# Altium schematic sheet LCD_Display.SchDoc
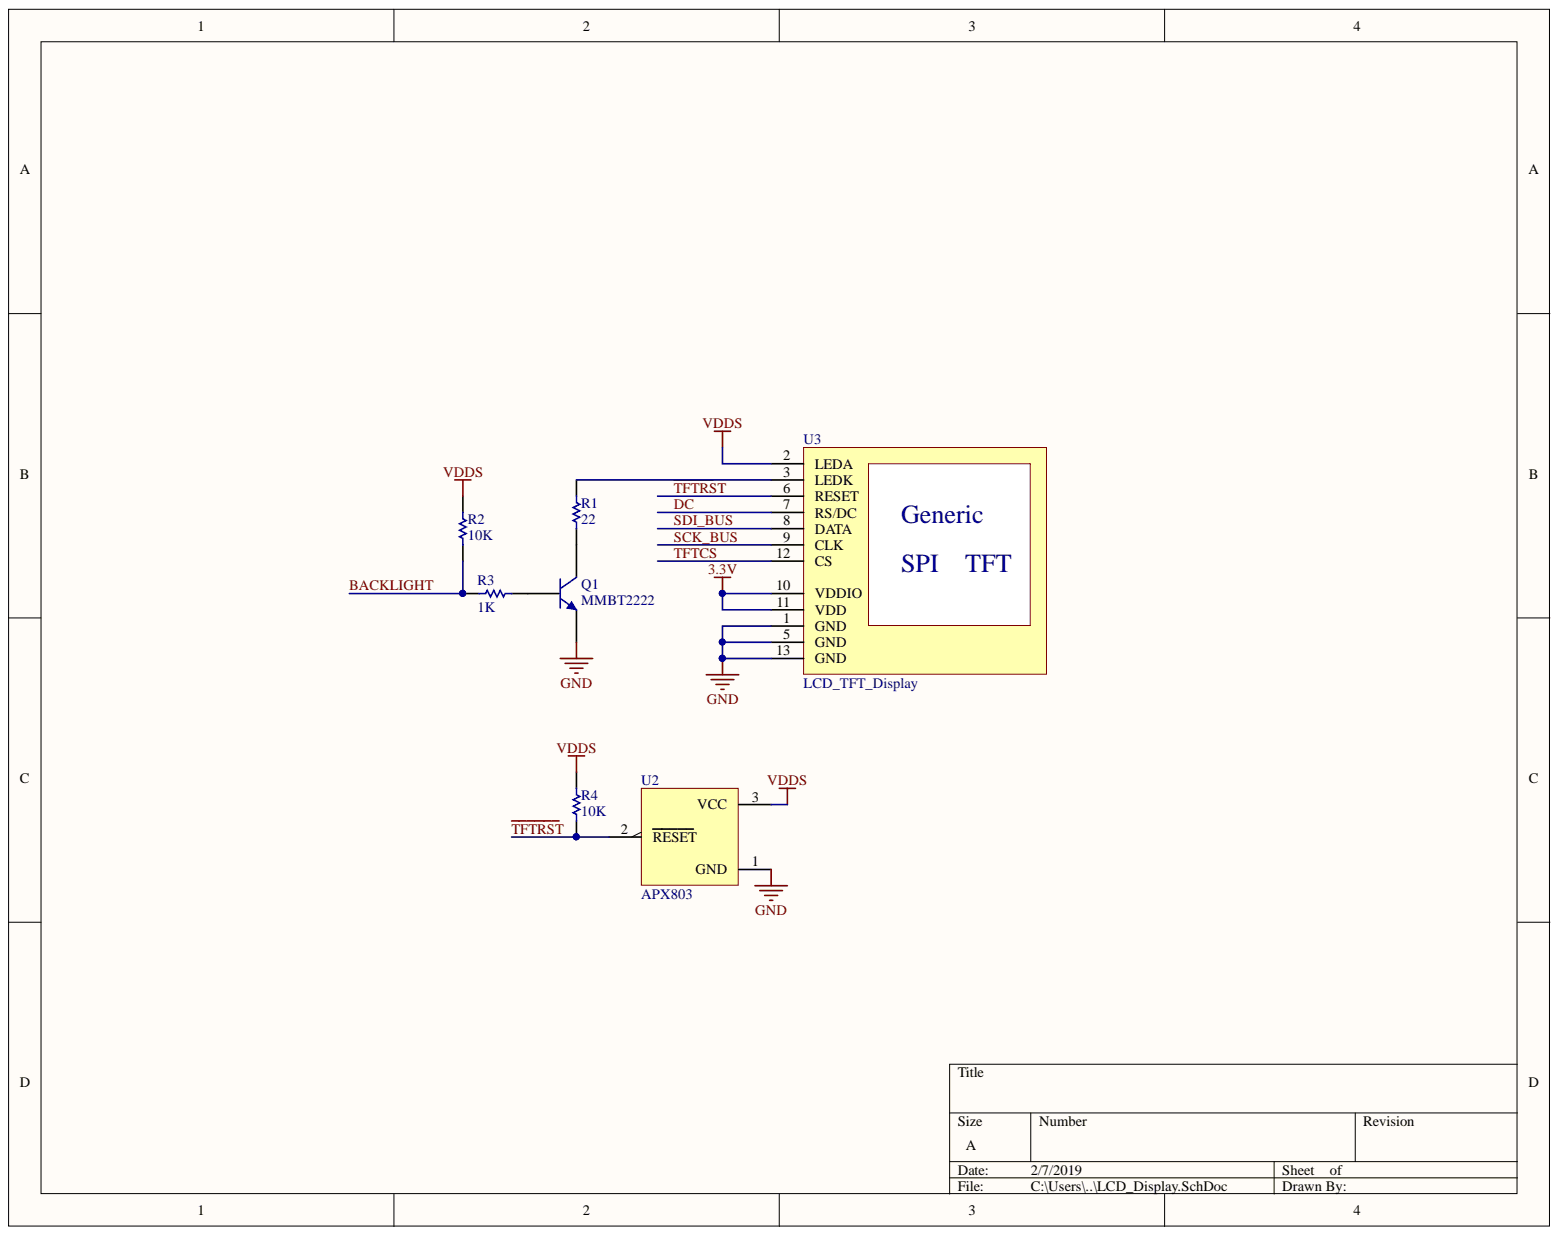
[diagram p0: LCD_Display.SchDoc]

## Parts
Q1  MMBT2222  [TO-92A]
R1  22  [0402[IMP]]
R2  10K  [0402[IMP]]
R3  1K  [0402[IMP]]
R4  10K  [0402[IMP]]
U2  APX803
U3  LCD_TFT_Display  [LCD_Ribbon]

## Nets
3.3V: U3.10(VDDIO) U3.11(VDD)
GND: Q1.1 U2.1 U3.1(GND) U3.5(GND) U3.13(GND)
VDDS: R2.2 R4.2 U2.3 U3.2(LEDA)
~{TFTRS}T: U3.6(RESET)
BACKLIGHT: R2.1 R3.1
DC: U3.7(RS/DC)
SCK_BUS: U3.9(CLK)
SDI_BUS: U3.8(DATA)
~{TFTRST}: R4.1 U2.2
TFTCS: U3.12(CS)
NetQ1_2: Q1.2 R3.2
NetQ1_3: Q1.3 R1.1
NetR1_2: R1.2 U3.3(LEDK)

## Library data of the parts
Q1 = 2N3904: NPN General Purpose Amplifier; Component Kind: Standard; ComponentLink1Description: Manufacturer Link; ComponentLink1URL: http://www.fairchildsemi.com/; ComponentLink2Description: Datasheet; ComponentLink2URL: http://www.fairchildsemi.com/ds/2N%2F2N3904.pdf; DatasheetVersion: 1997; LatestRevisionDate: 15-Jan-2003; LatestRevisionNote: PCB Footprint 'BCY-W3/D4.7' replaced; Library Name: Miscellaneous Devices.IntLib; PackageDescription: TO, Flat Index; 3 In-Line, Axial Leads; Body Dia. 4.5mm; Leads 0.51 x 0.48 mm (max); PackageReference: TO-92A; PackageVersion: Sep-1998; Published: 8-Jun-2000; Simulation: 2N3904; Publisher: Altium Limited
R1-R4 = RESISTOR: Component Kind: Standard; Library Name: Biosense_Altium_Library.IntLib
  R1: Value: 22
  R2 R4: Value: 10K
  R3: Value: 1K
U2 = APX803: Component Kind: Standard; Library Name: Biosense_Altium_Library.IntLib
U3 = LCD_TFT_Display: Component Kind: Standard; Library Name: Biosense_Altium_Library.IntLib
